# Altium schematic sheet PSU.SchDoc
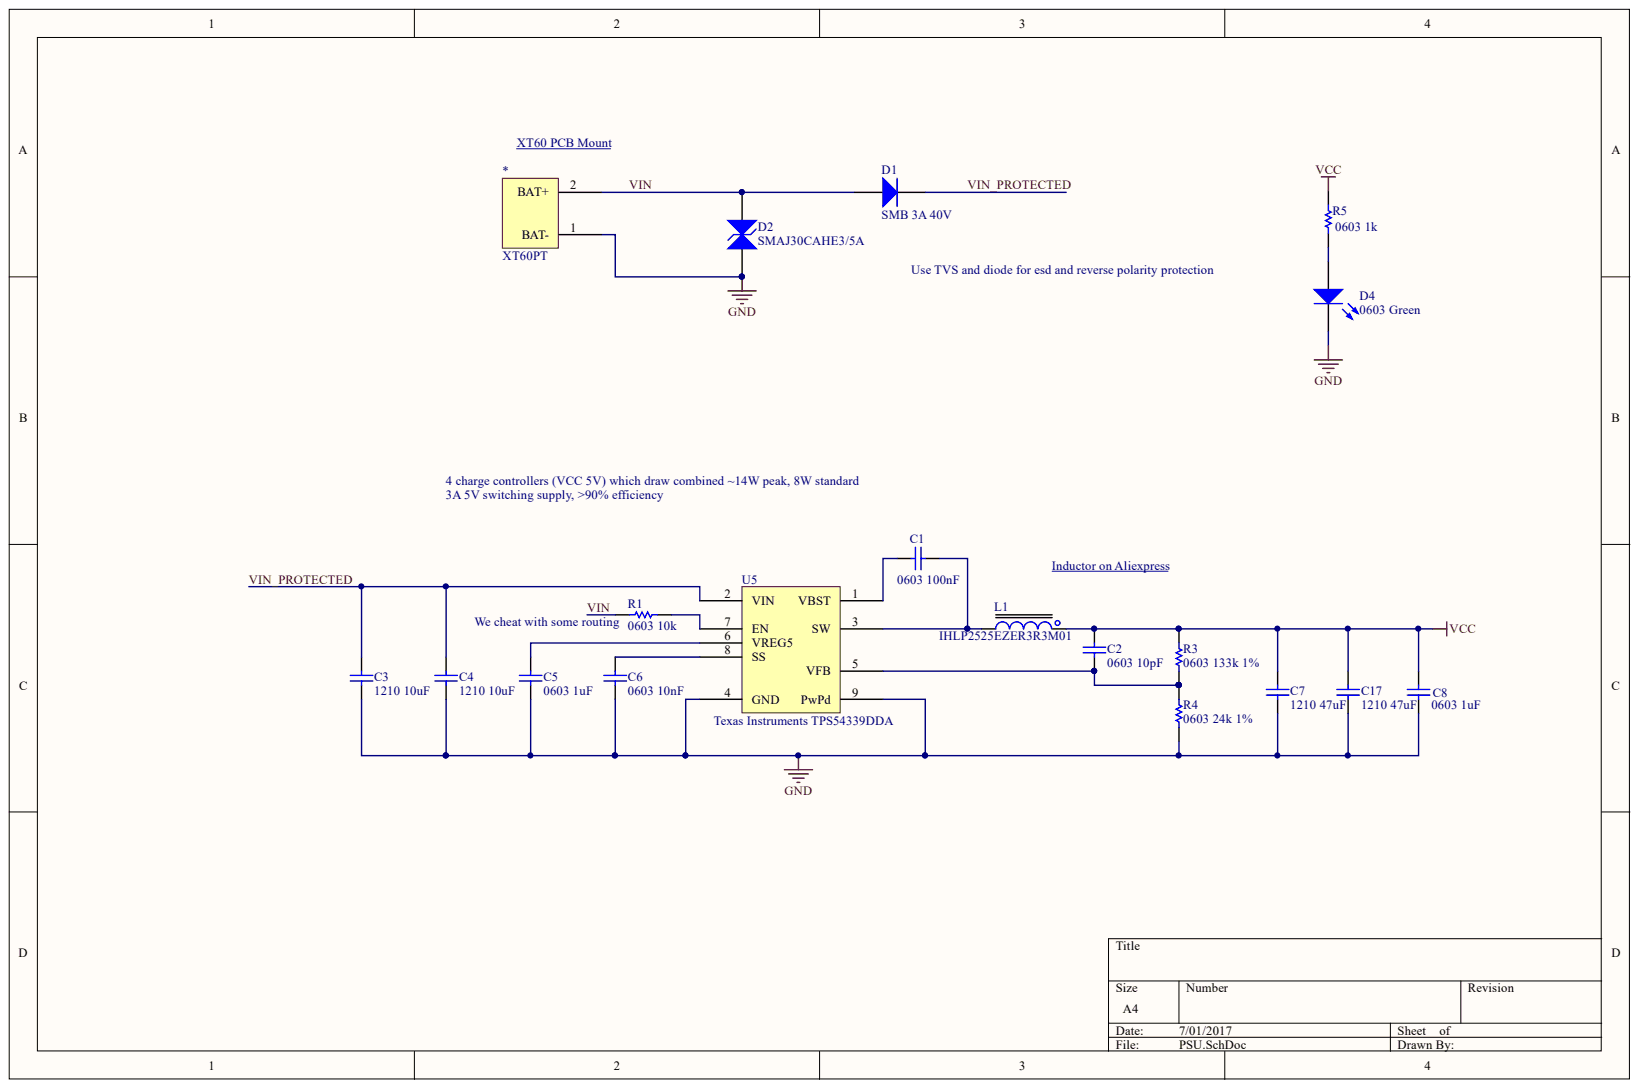
[diagram p0: PSU.SchDoc]

## Parts
*  XT60PT  [PCB-9eoogmb27k46wcqkg2ko-1]
C1  0603 100nF  Case/Package: 0603  [CAPC1608X90X35NL15T15]
C2  0603 10pF  Case/Package: 0603  [CAPC1608X90X35NL15T15]
C3  1210 10uF  Case/Package: 1210  [CAPC3225X279X50ML20T25]
C4  1210 10uF  Case/Package: 1210  [CAPC3225X279X50ML20T25]
C5  0603 1uF  Case/Package: 0603  [CAPC1608X90X35NL15T15]
C6  0603 10nF  Case/Package: 0603  [CAPC1608X90X35NL15T15]
C7  1210 47uF  Case/Package: 1210  [PCB-h26gble9h939l4c191wl-1]
C8  0603 1uF  Case/Package: 0603  [CAPC1608X90X35NL15T15]
C17  1210 47uF  Case/Package: 1210  [PCB-h26gble9h939l4c191wl-1]
D1  SMB 3A 40V  [PCB-816754-1]
D2  SMAJ30CAHE3/5A  [VISH-DO-214AC-2_M]
D4  0603 Green  Case/Package: 0603  [LED1608x55N]
L1  IHLP2525EZER3R3M01  [PCB-w72kg8ogivlijd3jpx38-1]
R1  0603 10k  Case/Package: 0603  [RESC1609X55X30NL10T20]
R3  0603 133k 1%  Case/Package: 0603  [RESC1608X55X30ML15T15]
R4  0603 24k 1%  Case/Package: 0603  [RESC1608X55X30ML15T15]
R5  0603 1k  [RESC1608X55X30ML15T15]
U5  Texas Instruments TPS54339DDA  [SOIC127P600X170_HS-9N]

## Nets
GND: *.1 C3.1 C4.1 C5.1 C6.1 C7.2 C8.1 C17.2 D2.1 D4.2 R4.1 U5.4(GND) U5.9(PwPd)
VCC: C2.2 C7.1 C8.2 C17.1 L1.2 R3.2 R5.2
VIN: *.2 D1.2 D2.2 R1.2
VIN_PROTECTED: C3.2 C4.2 D1.1 U5.2(VIN)
NetC1_1: C1.1 U5.1(VBST)
NetC1_2: C1.2 L1.1 U5.3(SW)
NetC2_1: C2.1 R3.1 R4.2 U5.5(VFB)
NetC5_2: C5.2 U5.6(VREG5)
NetC6_2: C6.2 U5.8(SS)
NetD4_1: D4.1 R5.1
NetR1_1: R1.1 U5.7(EN)

## Library data of the parts
* = CMP-ys0k18bgy9431xxyemrn-2: Amass PCB Mount XT60 Connector; Component Kind: Standard; Library Name: CommunityVault
C1 = CMP-955451-2: AVX   0603YC104K4Z2A; Capacitance: 1e-07 F; Capacitance Tolerance: ±10%; Dielectric Characteristic: X7R; Dielectric Material: Ceramic Multilayer; Lead-Free Status: Lead Free; Voltage Rating (DC): 16.0 V; Component Kind: Standard; Library Name: CommunityVault; Mounting Style: Surface Mount; Number of Pins: 2; OctopartID: eb1e206a3ac934d9; Octopart Url: https://octopart.com/0603yc104k4z2a-avx+interconnect+%2F+elco-41874609; Packaging: Cut Tape (CT); REACH SVHC Compliance: No SVHC; RoHS: Compliant
C2 = CMP-3554890-2: Multilayer Ceramic Capacitors MLCC - SMD/SMT 50volts 10pF 5% C0G; Capacitance: 1e-11 F; Dielectric Characteristic: C0G/NP0; Voltage Rating (DC): 50.0 V; Capacitance Tolerance: ±5%; Component Kind: Standard; Library Name: CommunityVault; Mounting Style: Surface Mount; OctopartID: f6c33fa4dac52904; Octopart Url: https://octopart.com/06035a100j4t2a-avx+interconnect+%2F+elco-7145824; Packaging: Reel; RoHS: Compliant
C3 C4 = CMP-127194-2: CAP CER 10UF 25V 10% X5R 1210; Capacitance: 1e-05 F; Dielectric Material: Ceramic Multilayer; Lead-Free Status: Lead Free; Voltage Rating (DC): 25.0 V; Capacitance Tolerance: ±10%; Component Kind: Standard; Dielectric Characteristic: X5R; Library Name: CommunityVault; Mounting Style: Surface Mount; Number of Pins: 2; OctopartID: afcd64022a74700a; Octopart Url: https://octopart.com/12103d106kat2a-avx+interconnect+%2F+elco-46506207; REACH SVHC Compliance: No SVHC; RoHS: Compliant; Size-Height: 0.00229 m; Size-Length: 0.0032 m; Size-Width: 0.0025 m
C5 C8 = CMP-126001-2: CAP CER 1UF 10V Y5V 0603; Capacitance: 1e-06 F; Capacitance Tolerance: +80/-20%; Insulation Resistance: 1e+11 Ω; Lead-Free Status: Lead Free; Voltage Rating (DC): 10.0 V; Component Kind: Standard; Dielectric Characteristic: Y5V; Library Name: CommunityVault; Mounting Style: Surface Mount; Number of Pins: 2; OctopartID: 127ddb77e8cdcdba; Octopart Url: https://octopart.com/0603zg105zat2a-avx+interconnect+%2F+elco-57302; Packaging: Cut Tape (CT); REACH SVHC Compliance: No SVHC; RoHS: Compliant; Size-Height: 0.00086 m; Size-Length: 0.0016 m; Size-Thickness: 0.0008636 m; Size-Width: 0.00081 m
C6 = CMP-171279-2: CAP CER 10000PF 25V 10% X7R 0603; Capacitance: 1e-08 F; Lead-Free Status: Lead Free; Voltage Rating (DC): 25.0 V; Capacitance Tolerance: ±10%; Component Kind: Standard; Dielectric Characteristic: X7R; Library Name: CommunityVault; Mounting Style: Surface Mount; Number of Pins: 2; OctopartID: 130ed873c884ccc9; Octopart Url: https://octopart.com/06033c103kat2a-avx+interconnect+%2F+elco-41418476; REACH SVHC Compliance: No SVHC; RoHS: Compliant
C7 C17 = CMP-cdd204dbeb8b1215-2: 1210 47 uF 10 V ±10% Tolerance X5R SMT Multilayer Ceramic Capacitor; Manufacturer Part Number: GRM32ER61A476KE20L; Capacitance: 47.0 µF; Case/Package (SI): 3225; Lead-Free Status: Lead Free; Voltage Rating (DC): 10.0 V; Capacitance Tolerance: ±10%; Component Kind: Standard; Dielectric Characteristic: X5R; Library Name: CommunityVault; Lifecycle Status: Active; Mounting Style: Surface Mount; Number of Pins: 2; OctopartID: cdd204dbeb8b1215; Octopart URL: http://octopart.com/grm32er61a476ke20l-murata-197439; Packaging: Cut Tape (CT), Tape & Reel (TR); REACH SVHC Compliance: No SVHC; RoHS: Compliant; Size-Height: 2.50 mm; Size-Length: 3.20 mm; Size-Thickness: 2.50 mm; Size-Width: 2.50 mm
D1 = CMP-816754-2: DIODE SCHOTTKY 100V 3A SMB; Lead-Free Status: Lead Free; Component Kind: Standard; Library Name: CommunityVault; Lifecycle Status: Active; Number of Pins: 2; OctopartID: bde07422cdd36227; Octopart Url: https://octopart.com/stps3h100u-stmicroelectronics-13129045; REACH SVHC Compliance: No SVHC; RoHS: Compliant
D2 = CMP-16576639-3: Transient Voltage Suppressor, 6.40 to 231 V, 30 V Stand-off Voltage, Bi-Directional, -55 to 155 degC, 2-pin DO-214AC, Pb-Free, Tape and Reel; Component Kind: Standard; Library Name: CommunityVault; OctopartID: 0a6fc1f01a3ad5a8; Octopart Url: https://octopart.com/smaj30cahe3%2F5a-vishay-43332915; RoHS: Compliant
D4 = CMP-1544853-3: Green 1.6 x 0.8 x 0.8 mm 130° Water Clear 6 mcd 2.1 V SMT LED; Manufacturer Part Number: LTST-C190GKT; Forward Voltage: 2.1 V; Lead-Free Status: Lead Free; Lens Type: Non-Diffused; Luminous Intensity: 0.006 cd; Wavelength: 5.65e-07 m; Color: Green; Component Kind: Standard; Library Name: CommunityVault; Mounting Style: Surface Mount, Vertical; OctopartID: b2f07bf2f42b6d37; Octopart URL: http://octopart.com/ltst-c190gkt-lite-on-549821; Packaging: Tape & Reel (TR); RoHS: Compliant; Size-Height: 0.0008 m; Size-Length: 0.0016 m; Size-Width: 0.0008 m; Viewing Angle: 130 °
L1 = CMP-55fd9e7d09658a3a-1: Surface Mount Power Inductor, IHLP-2525EZ-01 Series, 3.3 H, 20%, Shielded, 0.0209 ohm, 8 A; Inductance: 3.30 µH; Lead-Free Status: Lead Free; Resistance: 19.9 mΩ; Component Kind: Standard; Library Name: CommunityVault; Manufacturer Part Number: IHLP2525EZER3R3M01; Number of Pins: 2; OctopartID: 55fd9e7d09658a3a; Octopart URL: http://octopart.com/ihlp2525ezer3r3m01-vishay+dale-42629630; Packaging: Cut Tape (CT); REACH SVHC Compliance: No SVHC; RoHS: Compliant; Size-Height: 5.00 mm; Size-Length: 6.86 mm; Size-Width: 6.48 mm
R1 = CMP-1863099-2: RES SMD 10K OHM 0.1% 1/10W 0603; Lead-Free Status: Lead Free; Power Rating: 0.1 W; Resistance: 10000.0 Ω; Temperature Coefficient: 25.0 ppm/°C; Voltage Rating (DC): 50.0 V; Component Kind: Standard; Library Name: CommunityVault; Number of Pins: 2; OctopartID: e5122ede4c283ad5; Octopart Url: https://octopart.com/tnpw060310k0beea-vishay-46566492; Resistance Tolerance: ±0.1%; RoHS: Compliant
R3 = CMP-3663980-2: Thick Film Resistors - SMD 0603 133Kohms 1% Tol; Lead-Free Status: Lead Free; Power Rating: 0.1 W; Resistance: 133000.0 Ω; Voltage Rating (DC): 75.0 V; Component Kind: Standard; Library Name: CommunityVault; Lifecycle Status: Not Listed by Manufacturer; Mounting Style: Surface Mount; OctopartID: 765bbc1cf929a99c; Octopart Url: https://octopart.com/erj-3ekf1333v-panasonic-55421460; REACH SVHC Compliance: No SVHC; Resistance Tolerance: ±1%; RoHS: Compliant; Size-Height: 0.00045 m; Size-Length: 0.0016 m; Size-Width: 0.0008 m
R4 = CMP-3679912-2: Thick Film Resistors - SMD 0603 24Kohms 5% Tol; Lead-Free Status: Lead Free; Power Rating: 0.1 W; Resistance: 24000.0 Ω; Voltage Rating (DC): 75.0 V; Component Kind: Standard; Library Name: CommunityVault; Lifecycle Status: Not Listed by Manufacturer; Mounting Style: Surface Mount; OctopartID: 42e588a2cbdc9d22; Octopart Url: https://octopart.com/erj-3geyj243v-panasonic-55422047; Packaging: Cut Tape (CT); REACH SVHC Compliance: No SVHC; Resistance Tolerance: ±5%; RoHS: Compliant; Size-Height: 0.00045 m; Size-Length: 0.0016 m; Size-Width: 0.0008 m
R5 = CMP-3654795-5: Precision resistor, SMD [Panasonic Automotive & Industrial Systems] ERJ3EKF1001V Precision resistor, SMD; Manufacturer Part Number: ERJ-3EKF1001V; Power Rating: 100 mW; Resistance Tolerance: ±1%; Component Kind: Standard; Library Name: CommunityVault; Number of Pins: 2; Octopart URL: http://octopart.com/erj-3ekf1001v-panasonic-55403646; Size-Height: 450 µm
U5 = CMP-82774aeb049e5db0-1: 4.5V to 23V Input, 3A Synchronous Step-Down Converter with D-CAP2 Mode 8-SO PowerPAD -40 to 85; Lead-Free Status: Lead Free; Number of Outputs: 1; Output Current: 3.00 A; Quiescent Current: 850 µA; Case/Package: HSOP; Component Kind: Standard; Library Name: CommunityVault; Packaging: Cut Tape (CT)
